# Altium schematic sheet Integrated Preamp Sch.SchDoc
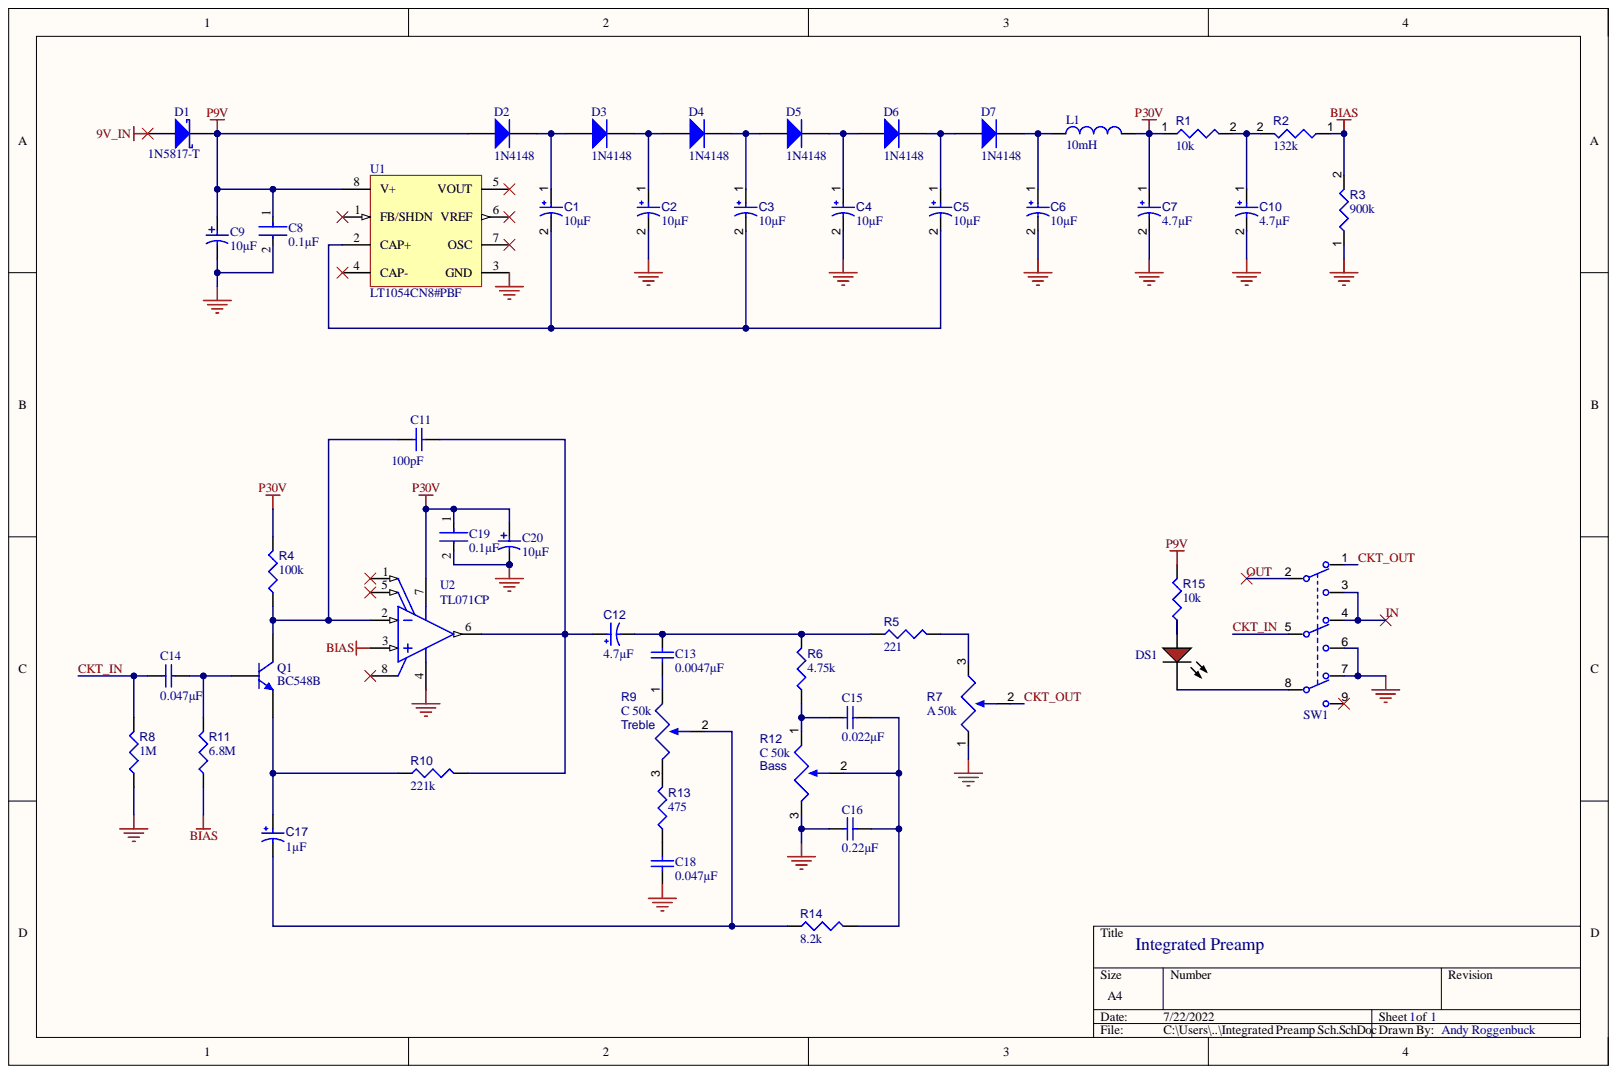
[diagram p0: Integrated Preamp Sch.SchDoc]

## Parts
C1  value 10µF  [CAPPR55-200-1100X500X1200]  (hidden comment: ECA1HM100)
C2  value 10µF  [CAPPR55-200-1100X500X1200]  (hidden comment: ECA1HM100)
C3  value 10µF  [CAPPR55-200-1100X500X1200]  (hidden comment: ECA1HM100)
C4  value 10µF  [CAPPR55-200-1100X500X1200]  (hidden comment: ECA1HM100)
C5  value 10µF  [CAPPR55-200-1100X500X1200]  (hidden comment: ECA1HM100)
C6  value 10µF  [CAPPR55-200-1100X500X1200]  (hidden comment: ECA1HM100)
C7  value 4.7µF  [CAPPR55-200-1100X500X1200]  (hidden comment: ECA1HM4R7)
C8  0.1µF  [FP-C322C104K5R5TA-MFG]
C9  10µF  [AVX-TAP475K016SCS]
C10  value 4.7µF  [CAPPR55-200-1100X500X1200]  (hidden comment: ECA1HM4R7)
C11  Capacitance: 100pF  [WIMA-MKS2D034701E00]  (hidden comment: MKS2D034701E00KSSD)
C12  value 4.7µF  [CAPPR55-200-1100X500X1200]  (hidden comment: ECA1HM4R7)
C13  Capacitance: 0.0047µF  [WIMA-MKS2D024701A00KSSD]  (hidden comment: CMP-2000-06717-1)
C14  .047µF  Capacitance: 0.047µF  [WIMA-MKS2D024701A00KSSD]
C15  Capacitance: 0.022µF  [WIMA-MKS2D024701A00KSSD]  (hidden comment: CMP-2000-06717-1)
C16  Capacitance: 0.22µF  [WIMA-MKS2D024701A00KSSD]  (hidden comment: CMP-2000-06717-1)
C17  value 1µF  [CAPPR55-200-1100X500X1200]  (hidden comment: ECA-1HHG010)
C18  Capacitance: 0.047µF  [WIMA-MKS2D024701A00KSSD]  (hidden comment: CMP-2000-06717-1)
C19  0.1µF  [FP-C322C104K5R5TA-MFG]
C20  10µF  [AVX-TAP475K016SCS]
D1  1N5817-T  [DIOD-DO-41-P-2]
D2  1N4148  [NXP-SOD27-2_V]
D3  1N4148  [NXP-SOD27-2_V]
D4  1N4148  [NXP-SOD27-2_V]
D5  1N4148  [NXP-SOD27-2_V]
D6  1N4148  [NXP-SOD27-2_V]
D7  1N4148  [NXP-SOD27-2_V]
DS1  LTL-1CHE  [INDPR60-254-520X380X650]  (value not drawn)
L1  10mH  [EPCS-B78108S-2_V1]
Q1  BC548B  [ONSC-TO-92-3-29-11]
R1  value 10k  [RESA60-630X240]  (hidden comment: MFR-25FBF52-10K)
R2  value 132k  [RESA60-630X240]  (hidden comment: MFR-25FBF52-100K)
R3  value 900k  [RESA60-630X240]  (hidden comment: MFR-25FBF52-1M)
R4  value 100k  [RESA60-630X240]  (hidden comment: MFR-25FBF52-100K)
R5  value 221  [RESA60-630X240]  (hidden comment: MFR-25FBF52-221R)
R6  value 4.75k  [RESA60-630X240]  (hidden comment: MFR-25FBF52-4K75)
R7  value A 50k  [PCB Mount Potentiometer]  (hidden comment: Potentiometer)
R8  value 1M  [RESA60-630X240]  (hidden comment: MFR-25FBF52-4K75)
R9  Treble  value C 50k  [PCB Mount Potentiometer]
R10  value 221k  [RESA60-630X240]  (hidden comment: MFR-25FBF52-221K)
R11  value 6.8M  [RESA60-630X240]  (hidden comment: MFR-25FBF52-6.8M)
R12  Bass  value C 50k  [PCB Mount Potentiometer]
R13  value 475  [RESA60-630X240]  (hidden comment: MFR-25FBF52-475R)
R14  value 8.2k  [RESA60-630X240]  (hidden comment: MFR-25FBF52-4K75)
R15  value 10k  [RESA60-630X240]  (hidden comment: MFR-25FBF52-10K)
SW1  MPG306F  [3PDT Stomp]  (value not drawn)  (mechanical)
U1  LT1054CN8#PBF  [LT-N8-8]
U2  TL071CP  [P0008A]

## Nets
9V_IN: D1.1
BIAS: R2.1 R3.2 R11.1 U2.3
GND: C2.2 C4.2 C6.2 C7.2 C8.2 C9.2 C10.2 C16.1 C18.1 C19.2 C20.2 R3.1 R7.1 R8.1 R12.3 SW1.6 SW1.7 U1.3(GND) U2.4
P9V: C8.1 C9.1 D1.2 D2.1 R15.2 U1.8(V+)
P30V: C7.1 C19.1 C20.1 L1.2 R1.1 R4.2 U2.7
CKT_IN: C14.1 R8.2 SW1.5
CKT_OUT: R7.2 SW1.1
IN: SW1.3 SW1.4
OUT: SW1.2
NetC1_1: C1.1 D2.2 D3.1
NetC1_2: C1.2 C3.2 C5.2 U1.2(CAP+)
NetC2_1: C2.1 D3.2 D4.1
NetC3_1: C3.1 D4.2 D5.1
NetC4_1: C4.1 D5.2 D6.1
NetC5_1: C5.1 D6.2 D7.1
NetC6_1: C6.1 D7.2 L1.1
NetC10_1: C10.1 R1.2 R2.2
NetC11_1: C11.1 Q1.1 R4.1 U2.2
NetC11_2: C11.2 C12.1 R10.2 U2.6
NetC12_2: C12.2 C13.2 R5.1 R6.2
NetC13_1: C13.1 R9.1
NetC14_2: C14.2 Q1.2 R11.2
NetC15_1: C15.1 R6.1 R12.1
NetC15_2: C15.2 C16.2 R12.2 R14.2
NetC17_1: C17.1 Q1.3 R10.1
NetC17_2: C17.2 R9.2 R14.1
NetC18_2: C18.2 R13.1
NetDS1_1: DS1.1 R15.1
NetDS1_2: DS1.2 SW1.8
NetR5_2: R5.2 R7.3
NetR9_3: R9.3 R13.2

Not connected: SW1 9; U1 1(FB/SHDN) 4(CAP-) 5(VOUT) 6(VREF) 7(OSC); U2 1 5 8

## Library data of the parts
C1-C6 = CMP-001-00025-7: Aluminum Electrolytic Capacitor, 10 uF, +/- 20%, 50 V, -40 to 85 degC, 2-Pin THD, RoHS, Bulk; Manufacturer: Panasonic; Ripple Current: 65mA; Voltage Rating: 50V; Capacitor Type: Electrolytic; Case/Package: Radial; Component Kind: Standard; Datasheet URL: https://industrial.panasonic.com/cdbs/www-data/pdf/RDF0000/ABA0000C1218.pdf; Datasheet Version: Rev. 05, 10/2016; Diameter: 5mm; Lead Pitch: 2mm; Manufacturer URL: http://www.panasonic.com/; Max Operating Temperature: 85°C; Min Operating Temperature: -40°C; Mounting Technology: TH; Package Description: 2-Pin Radial Lead, Dia 5 mm, Height 12 mm; Package Quantity: 200; Package Reference: CAPPR55-200-1100X500X1200; Packaging: Bulk; Pins: 2; Ripple Current (AC): 65mA; RoHS Compliant: True; Tolerance: 20%; Library Name: Andy R - Ferris State University
C7 C10 C12 = CMP-001-00033-7: Aluminum Electrolytic Capacitor, 4.7 uF, +/- 20%, 50 V, -40 to 85 degC, 2-Pin THD, RoHS, Bulk; Manufacturer: Panasonic; Ripple Current: 45mA; Voltage Rating: 50V; Capacitor Type: Electrolytic; Case/Package: Radial; Component Kind: Standard; Datasheet URL: https://industrial.panasonic.com/cdbs/www-data/pdf/RDF0000/ABA0000C1218.pdf; Datasheet Version: Rev. 05, 10/2016; Diameter: 5mm; Lead Pitch: 2mm; Manufacturer URL: http://www.panasonic.com/; Max Operating Temperature: 85°C; Min Operating Temperature: -40°C; Mounting Technology: TH; Package Description: 2-Pin Radial Lead, Dia 5 mm, Height 12 mm; Package Quantity: 200; Package Reference: CAPPR55-200-1100X500X1200; Packaging: Bulk; Pins: 2; Ripple Current (AC): 45mA; RoHS Compliant: True; Tolerance: 20%; Library Name: Andy R - Ferris State University
C8 C19 = CMP-03020-034661-1: CAP CER 0.1UF 50V X7R RADIAL; Capacitance: 100nF; Dielectric Material: Ceramic; Dissipation Factor: 2.5%; Lead Diameter: 0.51mm; Lead Length: 7mm; Lead/Base Style: Formed Leads; Tolerance: 10%; Case/Package: Radial; Component Kind: Standard; Construction: RADIAL; Depth: 3.18mm; Dielectric: X7R; Height: 3.18mm; Height - Seated (Max): 0.26inch; Lead Free: Lead Free; Lead Pitch: 5.08mm; Length: 5.08mm; Library Name: Altium Content Vault; Material: Ceramic; Max Operating Temperature: 125°C; Min Operating Temperature: -55°C; Mount: Through Hole; Number of Pins: 2; Package Quantity: 500; Packaging: Bulk; Radiation Hardening: No; REACH SVHC: No SVHC; RoHS Compliant: Yes; Series: Golden Max™; Termination: Radial; Voltage: 50V; Voltage Rating: 50V; Voltage Rating (DC): 50V; Weight: 0.0625lb; Width: 0.125inch
C9 C20 = CMP-2000-07562-1: Dipped Radial Capacitor, 4.7 uF, +/- 10%, 16 V, -55 to 125 degC, RoHS, Bulk; Manufacturer: AVX; Capacitance: 4.7uF; Dielectric Material: Tantalum; Dissipation Factor: 6%; ESR (Equivalent Series Resistance): 5Ohm; Lead Diameter: 0.5mm; Leakage Current: 600nA; Life (Hours): 1000Hour; Polarity: Polar; Voltage: 16V; Case\/Package: Dipped; Component Kind: Standard; Datasheet URL: http://datasheets.avx.com/tap.pdf; Datasheet Version: 08/2015; Diameter: 4.5mm; Height: 7.5mm; Height - Seated (Max): 0.295inch; Lead Free: LeadFree; Lead Pitch: 2.5mm; Length: 7.5mm; Library Name: Altium Content Vault; Manufacturer URL: http://www.avx.com/; Max Operating Temperature: 125degC; Min Operating Temperature: -55degC; Mount: PCB; Mounting Technology: ThroughHole; Number of Pins: 2; Package Description: 2-Pin Through Hole Device, Dia 4.5 mm, Height 7.5 mm; Package Quantity: 1000; Package Reference: TAP475K016SCS; Packaging: Bulk; Radiation Hardening: No; REACH SVHC: NoSVHC; RoHSCompliant: True; Termination: Radial; Tolerance: 10%; Voltage Rating: 16V; Voltage Rating (DC): 16V
C11 C13-C16 C18 = CMP-2000-06717-1: Metallized Polyester (PET) Capacitor, 0.47 uF, +/- 10%, 100 V DC, 63 V AC, -55 to 100 degC, 2-Pin THD, RoHS, Bulk; Manufacturer: Wima; Capacitor Dielectric Type: PET(Polyester); Capacitor Terminals: RadialLeaded; Product Range: MKS2Series; SVHC: NoSVHC(15-Jun-2015); Voltage Rating: 100V; Automotive Qualification Standard: -; Capacitance Tolerance: ±10%; Capacitor Case Style: -; Component Kind: Standard; Datasheet URL: http://www.wima.com/EN/WIMA_MKS_2.pdf; Datasheet Version: 04/2016; Lead Spacing: 5mm; Manufacturer URL: http://www.wima.com/; Mounting Technology: ThroughHole; Operating Temperature Max: 100°C; Operating Temperature Min: -55°C; Package Description: 2-Pin Through Hole Device, Body 7.2 x 4.5 mm, Pitch 5 mm; Package Reference: MKS2D034701E00; Packaging: Each
  C11: Library Name: Altium Content Vault
  C13-C16 C18: Library Name: Integrated Preamp Sch Lib.SchLib
C17 = CMP-001-00024-7: Aluminum Electrolytic Capacitor, 1 uF, +/- 20%, 50 V, -55 to 105 degC, 2-Pin THD, RoHS, Bulk; Manufacturer: Panasonic; Ripple Current: 10mA; Voltage Rating: 50V; Capacitor Type: Electrolytic; Case/Package: Radial; Component Kind: Standard; Datasheet URL: https://datasheet.ciiva.com/7275/94060-7275472.pdf; Datasheet Version: Mar-05; Diameter: 5mm; Lead Pitch: 2mm; Manufacturer URL: http://www.panasonic.com/; Max Operating Temperature: 105°C; Min Operating Temperature: -55°C; Mounting Technology: TH; Package Description: 2-Pin Radial Lead, Dia 5 mm, Height 12 mm; Package Quantity: 200; Package Reference: CAPPR55-200-1100X500X1200; Packaging: Bulk; Pins: 2; Ripple Current (AC): 10mA; RoHS Compliant: True; Tolerance: 20%; Library Name: Andy R - Ferris State University
D1 = CMP-2000-05521-1: Schottky Barrier Rectifier, 1 A, 20 V, -65 to 125 degC, 2-Pin DO-41, RoHS, Tape and Reel; Brand: DiodesIncorporated; Configuration: Single; If - Forward Current: 1A; Ifsm - Forward Surge Current: 25A; Ir - Reverse Current: 1000uA; Type: SchottkyDiode; Unit Weight: 0.010582oz; Vf - Forward Voltage: 0.75Vat3A; Vrrm - Repetitive Reverse Voltage: 20V; Component Kind: Standard; Datasheet URL: http://www.diodes.com/_files/datasheets/ds23001.pdf; Datasheet Version: Rev. 8-2, 05/2009; Factory Pack Quantity: 5000; Height: 2.72mm; Length: 5.21mm; Library Name: Altium Content Vault; Manufacturer: DiodesIncorporated; Manufacturer URL: http://www.diodes.com/; Maximum Operating Temperature: +125C; Minimum Operating Temperature: -65C; Mounting Style: ThroughHole; Mounting Technology: ThroughHole; Operating Temperature Range: -65Cto+125C; Package / Case: DO-41; Package Description: 2-Pin Through Hole Device, Body 4.635 x 2.36 mm, Pin Spacing 7.85 mm; Package Reference: DO-41-P-2; Packaging: Reel; Product: SchottkyRectifiers; Product Category: SchottkyDiodes&Rectifiers; RoHS: True; Series: 1N58; Technology: Si; Termination Style: ThroughHole; Width: 2.72mm
D2-D7 = CMP-004-00000-2: High Speed Diode, 100 V, 0.45 A, -65 to 175 degC, 2-Pin SOD27, RoHS, Bulk; Manufacturer: Fairchild; Elements: 1; Forward Current: 300mA; Forward Voltage: 1V; Max Surge Current: 4A; Peak Reverse Current: 5uA; Power: 500mW; Recovery Time: 4ns; Case/Package: DO; Component Kind: Standard; Datasheet URL: http://www.nxp.com/documents/data_sheet/1N4148_1N4448.pdf; Datasheet Version: Aug-04; Diameter: 1.91mm; Manufacturer URL: https://www.fairchildsemi.com/; Max Operating Temperature: 175°C; Min Operating Temperature: -65°C; Package Quantity: 2000; Package Reference: SOD27; Package URL: http://www.nxp.com/documents/data_sheet/1N4148_1N4448.pdf; Packaging: Bulk; Pins: 2; RoHS Compliant: True; Library Name: Andy R - Ferris State University
DS1 = CMP-007-00018-2: LED Uni-Color Red, 100 mW, 30 mA, -55 to 100 degC, 2-Pin THD, RoHS, Bulk; Manufacturer: Lite-On; Forward Current: 20mA; Forward Voltage: 2V; Lens Style: Dome; Luminous Intensity: 12.6mcd; Viewing Angle: 60degrees; Case/Package: Radial; Colour: Red; Component Kind: Standard; Datasheet URL: https://datasheet.ciiva.com/15117/getdatasheetpartid-187746-15117985.pdf; Datasheet Version: Dec-03; Illumination Colour: Red; Manufacturer URL: http://www.us.liteon.com/; Max Operating Temperature: 100°C; Min Operating Temperature: -55°C; Mounting Technology: TH; Nominal Vf: 2V; Package Description: 2-Pin Through Hole Device, Dia 3.8 mm, Pitch 2.54 mm; Package Quantity: 1000; Package Reference: LTL-1CHE; Packaging: Bulk; Pins: 2; RoHS Compliant: True; Library Name: Andy R - Ferris State University
L1 = CMP-0816-00001-1: RF Choke, 470 uH +/- 5%, 170 mA, Dia 4 mm, Length 9.2 mm, Axial Leads, RoHS, Tape and Reel; Manufacturer: EPCOS; PartNumber: B78108S1474J; FQ: 0.796 MHz; Fres: 2.2 MHz Min.; IR: 170 mA; LR: 470 uH; Q: 70 Min.; R: 7.9 Ohm Max.; Tolerance: +/- 5%; Component Kind: Standard; ComponentLink1Description: Manufacturer URL; ComponentLink1URL: http://www.epcos.com/; ComponentLink2Description: Datasheet; ComponentLink2URL: http://www.epcos.com/inf/30/db/ind_2008/b78108_148s.pdf; DatasheetVersion: Jun-12; Library Name: Altium Content Vault; Mounting Technology: Through Hole; PackageDescription: 2-Pin RF Choke, Dia 4 mm, Length 9.2 mm; PackageReference: B78108S-2; Packing: Tape and Reel; RoHS: TRUE
Q1 = CMP-1048-01456-1: Amplifier Transistor, NPN Silicon, 3-Pin TO-92, Bulk Box; Manufacturer: On Semiconductor; fT Min (MHz): 150; IC Continuous (A): 0.1; Component Kind: Standard; ComponentLink1Description: Manufacturer URL; ComponentLink1URL: http://www.onsemi.com/; ComponentLink2Description: Datasheet; ComponentLink2URL: http://www.onsemi.com/pub_link/Collateral/BC546-D.PDF; ComponentLink3Description: Package Specification; ComponentLink3URL: http://www.onsemi.cn/pub_link/Collateral/2N6426-D.PDF; DatasheetVersion: Rev. 7; Library Name: Altium Content Vault; Mounting Technology: Through Hole; PackageDescription: 3-Pin Transistor Outline, Body 4.825 x 3.685 mm, Pitch 1.27 mm; PackageReference: TO-92-3-29-11_AM_SL; PackageVersion: Rev. AM; Packing: Bulk Box; PartNumber: BC548B; Polarity: NPN; RoHS: FALSE
R1 R15 = CMP-009-00047-4: Axial Resistor, 10 KOhm, +/- 1%, 0.25 W, -55 to 155 degC, 2-Pin THD, RoHS, Bulk; Manufacturer: Yageo; Power: 250mW; Voltage Rating: 250V; Case/Package: Axial; Component Kind: Standard; Datasheet URL: http://www.yageo.com/documents/recent/Yageo%20LR_MFR_2013.pdf; Datasheet Version: Apr-13; Manufacturer URL: http://www.yageo.com/; Max Operating Temperature: 155°C; Min Operating Temperature: -55°C; Mounting Technology: TH; Package Description: 2-Pin Axial Lead, Dia 2.4 mm, Body Length 6.3 mm; Package Quantity: 200; Package Reference: MFR-25; Packaging: Bulk; RoHS Compliant: True; Tolerance: 1%; Library Name: Andy R - Ferris State University
R2 R4 = CMP-009-00045-4: Axial Resistor, 100 KOhm, +/- 1%, 0.25 W, -55 to 155 degC, 2-Pin THD, RoHS, Bulk; Manufacturer: Yageo; Power: 250mW; Voltage Rating: 250V; Case/Package: Axial; Component Kind: Standard; Datasheet URL: http://www.yageo.com/documents/recent/Yageo%20LR_MFR_2013.pdf; Datasheet Version: Apr-13; Manufacturer URL: http://www.yageo.com/; Max Operating Temperature: 155°C; Min Operating Temperature: -55°C; Mounting Technology: TH; Package Description: 2-Pin Axial Lead, Dia 2.4 mm, Body Length 6.3 mm; Package Quantity: 200; Package Reference: MFR-25; Packaging: Bulk; RoHS Compliant: True; Tolerance: 1%; Library Name: Andy R - Ferris State University
R3 = CMP-009-00049-5: Axial Resistor, 1 MOhm, +/- 1%, 250 mW, -55 to 155 degC, 2-Pin THD, RoHS, Bulk; Manufacturer: Yageo; Power: 250mW; Voltage Rating: 250V; Case/Package: Axial; Component Kind: Standard; Datasheet URL: http://www.yageo.com/documents/recent/Yageo%20LR_MFR_2013.pdf; Datasheet Version: Apr-13; Manufacturer URL: http://www.yageo.com/; Max Operating Temperature: 155°C; Min Operating Temperature: -55°C; Mounting Technology: TH; Package Description: 2-Pin Axial Lead, Dia 2.4 mm, Body Length 6.3 mm; Package Quantity: 200; Package Reference: MFR-25; Packaging: Bulk; RoHS Compliant: True; Tolerance: 1%; Library Name: Andy R - Ferris State University
R5 = CMP-009-00051-4: Axial Resistor, 221 Ohm, +/- 1%, 250 mW, -55 to 155 degC, 2-Pin THD, RoHS, Bulk; Manufacturer: Yageo; Power: 250mW; Voltage Rating: 250V; Case/Package: Axial; Component Kind: Standard; Datasheet URL: http://www.yageo.com/documents/recent/Yageo%20LR_MFR_2013.pdf; Datasheet Version: Apr-13; Manufacturer URL: http://www.yageo.com/; Max Operating Temperature: 155°C; Min Operating Temperature: -55°C; Mounting Technology: TH; Package Description: 2-Pin Axial Lead, Dia 2.4 mm, Body Length 6.3 mm; Package Quantity: 200; Package Reference: MFR-25; Packaging: Bulk; RoHS Compliant: True; Tolerance: 1%; Library Name: Andy R - Ferris State University
R6 R8 R14 = CMP-009-00059-4: Axial Resistor, 4.75 KOhm, +/- 1%, 0.25 W, -55 to 155 degC, 2-Pin THD, RoHS, Bulk; Manufacturer: Yageo; Power: 250mW; Voltage Rating: 250V; Case/Package: Axial; Component Kind: Standard; Composition: MetalFilm; Datasheet URL: http://www.yageo.com/documents/recent/Yageo%20LR_MFR_2013.pdf; Datasheet Version: Apr-13; Manufacturer URL: http://www.yageo.com/; Max Operating Temperature: 155°C; Min Operating Temperature: -55°C; Mounting Technology: TH; Package Description: 2-Pin Axial Lead, Dia 2.4 mm, Body Length 6.3 mm; Package Quantity: 200; Package Reference: MFR-25; Packaging: Bulk; RoHS Compliant: True; Tolerance: 1%; Library Name: Andy R - Ferris State University
R9 R12 = Potentiometer: Component Kind: Standard; Library Name: Integrated Preamp Sch Lib.SchLib
R10 = CMP-009-00050-4: Axial Resistor, 221 KOhm, +/- 1%, 0.25 W, -55 to 155 degC, 2-Pin THD, RoHS, Bulk; Manufacturer: Yageo; Power: 250mW; Voltage Rating: 250V; Case/Package: Axial; Component Kind: Standard; Datasheet URL: http://www.yageo.com/documents/recent/Yageo%20LR_MFR_2013.pdf; Datasheet Version: Apr-13; Manufacturer URL: http://www.yageo.com/; Max Operating Temperature: 155°C; Min Operating Temperature: -55°C; Mounting Technology: TH; Package Description: 2-Pin Axial Lead, Dia 2.4 mm, Body Length 6.3 mm; Package Quantity: 200; Package Reference: MFR-25; Packaging: Bulk; RoHS Compliant: True; Tolerance: 1%; Library Name: Andy R - Ferris State University
R11 = CMP-009-00049-5: Axial Resistor, 6.8 MOhm, +/- 1%, 250 mW, -55 to 155 degC, 2-Pin THD, RoHS, Bulk; Manufacturer: Yageo; Power: 250mW; Voltage Rating: 250V; Case/Package: Axial; Component Kind: Standard; Datasheet URL: http://www.yageo.com/documents/recent/Yageo%20LR_MFR_2013.pdf; Datasheet Version: Apr-13; Manufacturer URL: http://www.yageo.com/; Max Operating Temperature: 155°C; Min Operating Temperature: -55°C; Mounting Technology: TH; Package Description: 2-Pin Axial Lead, Dia 2.4 mm, Body Length 6.3 mm; Package Quantity: 200; Package Reference: MFR-25; Packaging: Bulk; RoHS Compliant: True; Tolerance: 1%; Library Name: Andy R - Ferris State University
R13 = CMP-009-00056-4: Axial Resistor, 475 Ohm, +/- 1%, 0.25 W, -55 to 155 degC, 2-Pin THD, RoHS, Bulk; Manufacturer: Yageo; Power: 250mW; Voltage Rating: 250V; Case/Package: Axial; Component Kind: Standard; Datasheet URL: http://www.yageo.com/documents/recent/Yageo%20LR_MFR_2013.pdf; Datasheet Version: Apr-13; Manufacturer URL: http://www.yageo.com/; Max Operating Temperature: 155°C; Min Operating Temperature: -55°C; Mounting Technology: TH; Package Description: 2-Pin Axial Lead, Dia 2.4 mm, Body Length 6.3 mm; Package Quantity: 200; Package Reference: MFR-25; Packaging: Bulk; RoHS Compliant: True; Tolerance: 1%; Library Name: Andy R - Ferris State University
SW1 = CMP-03402-000028-1: SWITCH PUSHBUTTON 3PDT 6A 125V; Actuator Length: 0.315inch; Actuator Material: Resin; Actuator Type: Round, Button; Contact Resistance: 10mR; Current Rating: 4A; Electrical Life: 50000Cycles; Illumination Type: Illuminated; Max Voltage Rating (AC): 250V; Switch Function: On-Mom; Voltage Rating (DC): 28V; Contact Current Rating: 6A; Contact Material: Copper; Contact Plating: Gold; ELV: Compliant; Housing Material: Thermoset; Lead Free: Contains Lead; Length: 17.8mm; Max Operating Temperature: 80°C; Min Operating Temperature: -20°C; Mount: Panel; Orientation: Straight; Packaging: Bulk; REACH SVHC: No SVHC; RoHS Compliant: Yes; Series: MPG; Termination: Lug; Throw Configuration: 3PDT; Type: Switch; Voltage Rating (AC): 250V; Library Name: Integrated Preamp Sch Lib.SchLib
U1 = CMP-0475-00280-1: Switched-Capacitor Voltage Converter with Regulator, 3.5 to 15 V Vin, Adjustable Vout, 8-pin DIP (N8-8), 0 to 100 degC, Pb-Free; Manufacturer: Linear Technology; Frequency(kHz): 25; Ishutdown(uA): 100; Isupply(mA): 2.5; Vin Max(V): 15; Vin Min(V): 3.5; Voutput: Adj; Component Kind: Standard; ComponentLink1Description: Manufacturer URL; ComponentLink1URL: http://www.linear.com/; ComponentLink2Description: Datasheet; ComponentLink2URL: http://cds.linear.com/docs/en/datasheet/1054lfg.pdf; ComponentLink3Description: Package Specification; ComponentLink3URL: http://www.linear.com/docs/en/packaging/05081510_I_N8.pdf; DatasheetVersion: Rev. G; Library Name: Altium Content Vault; Max Iout(mA): 100; Mounting Technology: Through Hole; PackageDescription: 8-Pin PDIP, Body 10.16 x 6.48 mm, Pitch 2.54 mm; PackageReference: N8-8; PackageVersion: Rev. I, 07/2011; PartNumber: LT1054CN8#PBF; RoHS: TRUE
U2 = CMP-0017-00972-2: Low-Noise JFET-Input General-Purpose Operational Amplifier, 7 to 36 V, 0 to 70 degC, 8-pin DIP (P8), Pb-Free (RoHS); Manufacturer: Texas Instruments; CMRR(Min)(dB): 70; GBW(Typ)(MHz): 3; IIB(Max)(pA): 200; Iq per channel(Max)(mA): 2.5; Number of Channels: 1; Offset Drift(Typ)(uV/C): 18; Rating: Military; Slew Rate(Typ)(V/us): 13; Sub Family: General Purpose Amplifier, High Supply Voltage Amplifier (>=30V); Total Supply Voltage(Max)(+5V is 5, +/-5V is 10): 36; Total Supply Voltage(Min)(+5V is 5, +/-5V is 10): 7; Vio (25C)(Max)(mV): 10; Code_JEDEC: MS-001; Component Kind: Standard; ComponentLink1Description: Manufacturer URL; ComponentLink1URL: http://www.ti.com/; ComponentLink2Description: Datasheet; ComponentLink2URL: http://www.ti.com/lit/ds/symlink/tl071.pdf; ComponentLink3Description: Package Specification; ComponentLink3URL: http://www.ti.com/litv/pdf/mpdi001b; DatasheetVersion: SLOS080J; Eco-Plan: Pb-Free (RoHS); Library Name: Altium Content Vault; Mounting Technology: Through Hole; PackageDescription: 8-Pin Plastic In-Line, Body 9.59 x 6.35 mm, Pitch 2.54 mm; PackageReference: P0008A; PackageVersion: 4040082/E, 04/2010; PartNumber: TL071CP; Vn at 1kHz(Typ)(nV/rtHz): 18
R7 = Potentiometer: Component Kind: Standard; Library Name: Integrated Preamp Sch Lib.SchLib
